# Altium schematic sheet POWERMANAGE2.SchDoc
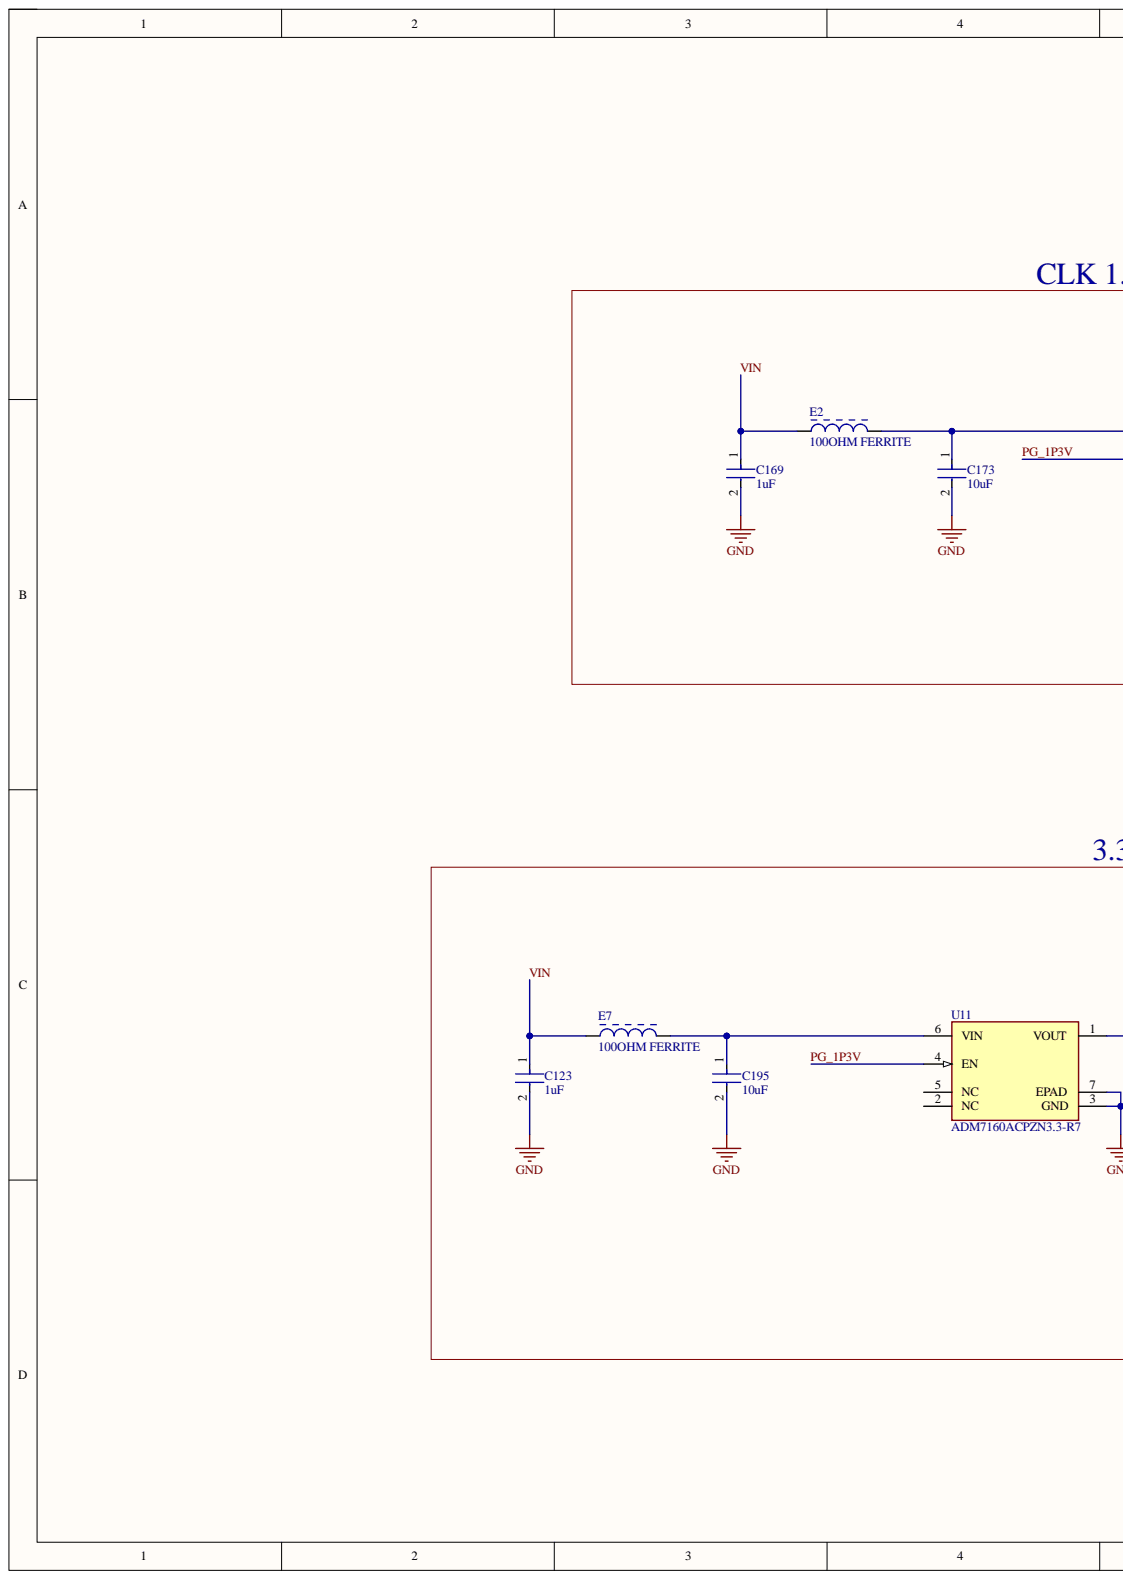
[diagram p0: POWERMANAGE2.SchDoc, left]
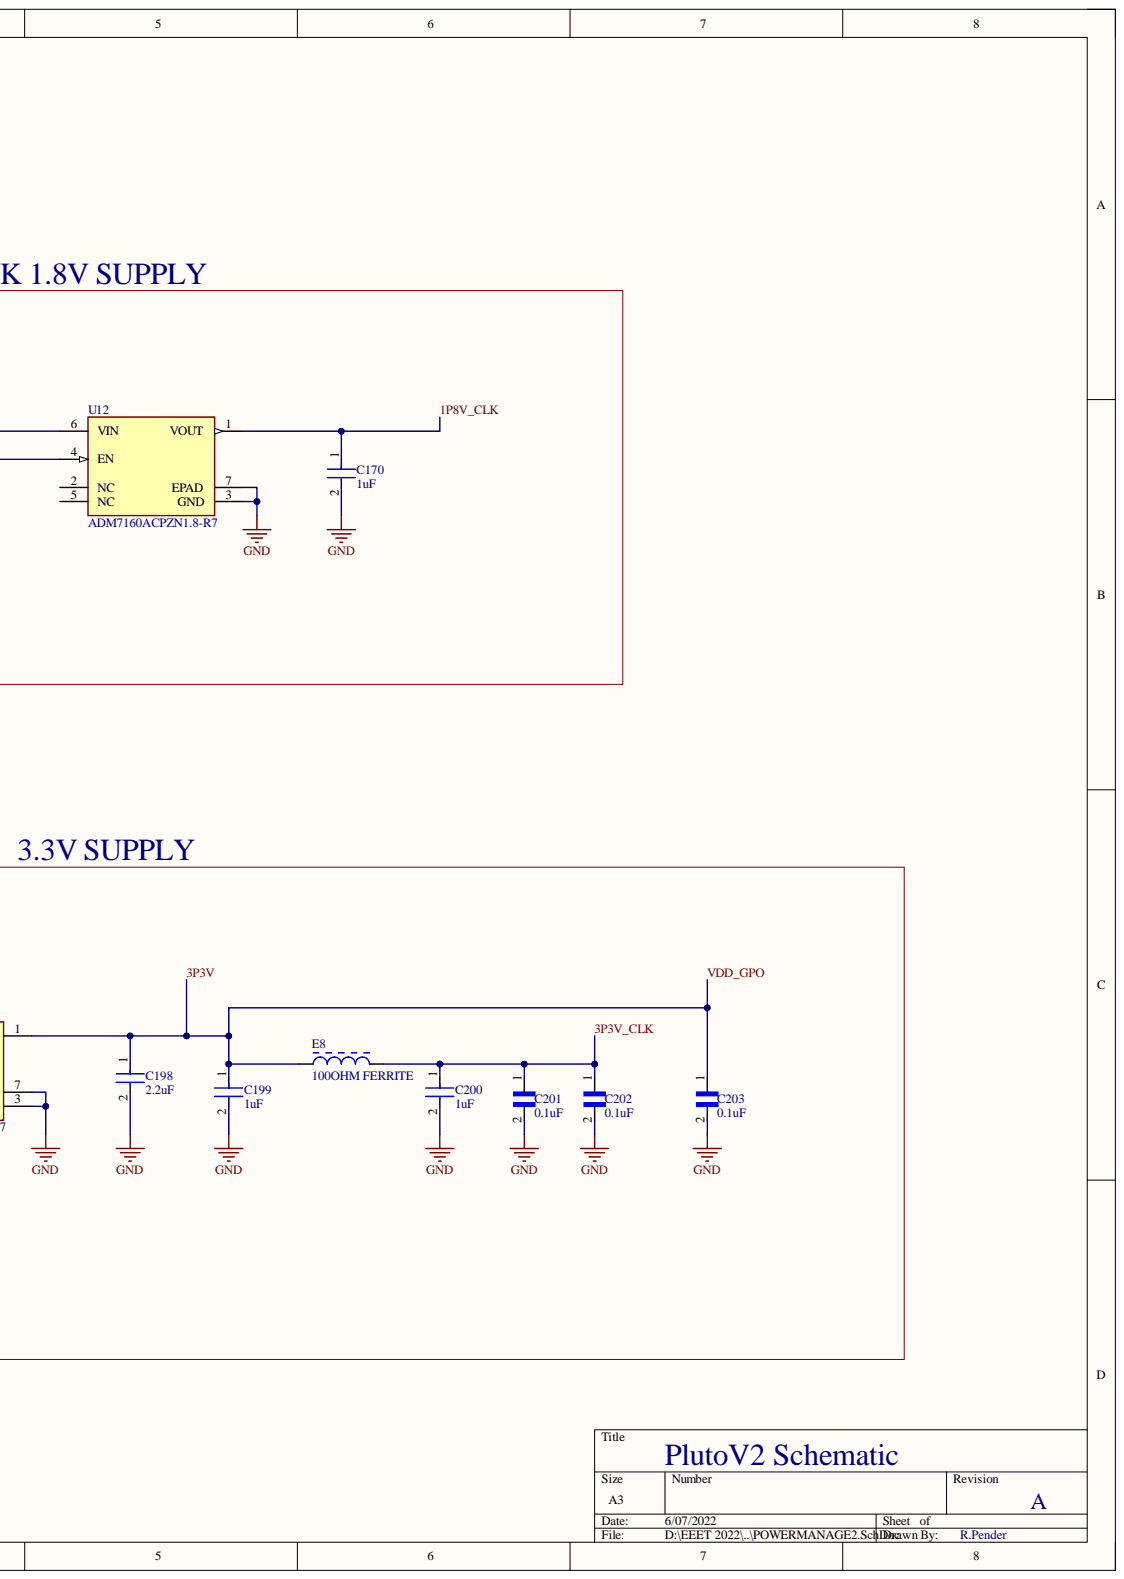
[diagram p1: POWERMANAGE2.SchDoc, right]

## Parts
C123  1uF  [FP-0402-L_1_0_0_05-W_0_5-IPC_A]
C169  1uF  [FP-0402-L_1_0_0_05-W_0_5-IPC_A]
C170  1uF  [FP-0402-L_1_0_0_05-W_0_5-IPC_B]
C173  10uF  [FP-GRM188-0_15-MFG]
C195  10uF  [FP-GRM188-0_15-MFG]
C198  2.2uF  [FP-GRM188-0_2-MFG]
C199  1uF  [FP-0402-L_1_0_0_05-W_0_5-IPC_A]
C200  1uF  [FP-0402-L_1_0_0_05-W_0_5-IPC_A]
C201  0.1uF  [CAPC0603X33N]
C202  0.1uF  [CAPC0603X33N]
C203  0.1uF  [CAPC0603X33N]
E2  100OHM FERRITE  [TDK-MPZ2012S101A-2_V]
E7  100OHM FERRITE  [TDK-MPZ2012S101A-2_V]
E8  100OHM FERRITE  [TDK-MPZ2012S101A-2_V]
U11  ADM7160ACPZN3.3-R7  [FP-CP-6-3-IPC_A]
U12  ADM7160ACPZN1.8-R7  [FP-CP-6-3-IPC_C]

## Nets
GND: C123.2 C169.2 C170.2 C173.2 C195.2 C198.2 C199.2 C200.2 C201.2 C202.2 C203.2 U11.3(GND) U11.7(EPAD) U12.3(GND) U12.7(EPAD)
1P8V_CLK: C170.1 U12.1(VOUT)
3P3V: C198.1 C199.1 C203.1 E8.1 U11.1(VOUT)
3P3V_CLK: C200.1 C201.1 C202.1 E8.2
PG_1P3V: U11.4(EN) U12.4(EN)
VIN: C123.1 C169.1 E2.1 E7.1
NetC173_1: C173.1 E2.2 U12.6(VIN)
NetC195_1: C195.1 E7.2 U11.6(VIN)

Not connected: U11 2(NC) 5(NC); U12 2(NC) 5(NC)

## Library data of the parts
C123 C169 C170 C199 C200 = CMP-14477-000104-2: None; Dielectric: X5R; Tolerance: 10%; Voltage Rating: 16V; Capacitance: 1uF; Case Code (Imperial): 0402; Case Code (Metric): 1005; Case/Package: 0402; Component Kind: Standard; Construction: Flat; Depth: 0.5mm; Halogen Free: Halogen Free; Height: 0.5mm; Length: 1mm; Library Name: Altium Content Vault; Max Operating Temperature: 85°C; Min Operating Temperature: -55°C; Mount: Surface Mount; Number of Pins: 2; Package Quantity: 1; Packaging: Tape and Reel; Radiation Hardening: No; RoHS Compliant: Yes; Series: M; Simulation: EMK105BJ105_V-F; Termination: SMD/SMT; Thickness: 0.022inch; Voltage Rating (DC): 16V; Width: 0.02inch
C173 C195 = CMP-06035-003612-1: Chip Multilayer Ceramic Capacitors for General Purpose, 0603, 10uF, X5R, 15%, 20%, 10V; Capacitance: 10uF; Case Code (Imperial): 0603; Case Code (Metric): 1608; Case/Package: 0603; Component Kind: Standard; Depth: 0.8mm; Dielectric: X5R; Height: 0.8mm; Lead Free: Lead Free; Length: 1.6mm; Library Name: Altium Content Vault; Material: Ceramic; Max Operating Temperature: 85°C; Min Operating Temperature: -55°C; Mount: Surface Mount; Package Quantity: 4000; Packaging: Tape and Reel; Termination: SMD/SMT; Thickness: 0.035inch; Tolerance: 20%; Voltage Rating: 10V; Voltage Rating (DC): 10V; Weight: 0.000222oz; Width: 0.8mm
C198 = CMP-06035-023692-1: Chip Multilayer Ceramic Capacitors for General Purpose, 0603, 2.2uF, X5R, 15%, 10%, 50V; Capacitance: 2.2uF; Case Code (Imperial): 0603; Case Code (Metric): 1608; Case/Package: 0603; Component Kind: Standard; Dielectric: X5R; Height: 0.8mm; Length: 0.063inch; Library Name: Altium Content Vault; Max Operating Temperature: 85°C; Min Operating Temperature: -55°C; Mount: Surface Mount; Package Quantity: 10000; Packaging: Tape and Reel; Resistor Type: Ceramic; Termination: SMD/SMT; Thickness: 0.039inch; Tolerance: 10%; Voltage Rating: 50V; Voltage Rating (DC): 50V; Weight: 0.000222oz; Width: 0.8mm
C201-C203 = GRM033R61C104ME84D: CAP 0.1UF 16VDC X5R 20% SMD 0201 PAPER TAPE; Availability: Unavailable; Component Kind: Standard; Library Name: GRM033R61C104ME84D.IntLib; MP: GRM033R61C104ME84D; Package: None; Price: None
E2 E7 E8 = CMP-2000-05399-1: Chip Bead for Power Line, 100 Ohm, +/- 25%, 4 A, -55 to 125 degC, 0805 (2012 Metric), RoHS, Tape and Reel; Brand: TDK; Case Height: 0.85mm; Case Length: 2mm; Case Width: 1.25mm; Impedance: 100Ohms; Maximum DC Current: 4A; Maximum DC Resistance: 20mOhms; Test Frequency: 100MHz; Component Kind: Standard; Datasheet URL: http://www.farnell.com/datasheets/1750041.pdf; Datasheet Version: Jul-13; Dimensions: 1.25mmWx2mmLx0.85mmH; Factory Pack Quantity: 4000; Library Name: Altium Content Vault; Manufacturer: TDK; Manufacturer URL: http://www.tdk.com/; Operating Temperature Range: -55Cto+125C; Package / Case: 0805(2012metric); Package Description: 2-Pin Surface Mount Device, Body 2 x 1.25 mm; Package Reference: MPZ2012S101A-2; Packaging: Reel; Product: FerriteChipBeads; Product Category: EMIFilterBeads,Chips&Arrays; RoHS: Details; Termination Style: SMD/SMT; Tolerance: 25%
U11 = CMP-09138-000129-1: IC REG LINEAR 3.3V 200MA 6LFCSP; Accuracy: 1%; Dropout Voltage: 150mV; Max Input Voltage: 5.5V; Max Supply Current: 265uA; Min Current Limit: 220mA; Min Input Voltage: 2.2V; Number of Outputs: 1; Operating Supply Current: 265mA; Output Type: Fixed; Polarity: Positive; Power Supply Rejection Ratio (PSRR): 49dB; Case/Package: TSOT; Component Kind: Standard; Contact Plating: Gold; Lead Free: Contains Lead; Library Name: Altium Content Vault; Max Operating Temperature: 125°C; Max Output Current: 200mA; Min Operating Temperature: -40°C; Mount: Surface Mount; Number of Pins: 6; Number of Regulators: 1; Output Current: 200mA; Output Voltage: 3.3V; Package Quantity: 3000; Packaging: Tape and Reel; Quiescent Current: 265uA; RoHS Compliant: Yes
U12 = CMP-09138-000112-1: IC REG LINEAR 1.8V 200MA 6LFCSP; Accuracy: 1%; Dropout Voltage: 230mV; Max Input Voltage: 5.5V; Max Supply Current: 265uA; Min Current Limit: 220mA; Min Input Voltage: 2.2V; Number of Outputs: 1; Operating Supply Current: 265mA; Output Type: Fixed; Polarity: Positive; Power Supply Rejection Ratio (PSRR): 49dB; Case/Package: TSOT; Component Kind: Standard; Contact Plating: Gold; Lead Free: Contains Lead; Library Name: Altium Content Vault; Max Operating Temperature: 125°C; Max Output Current: 200mA; Min Operating Temperature: -40°C; Mount: Surface Mount; Number of Pins: 6; Number of Regulators: 1; Output Current: 200mA; Output Voltage: 1.8V; Package Quantity: 3000; Packaging: Tape and Reel; Quiescent Current: 265uA; RoHS Compliant: Yes
